# Altium schematic sheet Main.SchDoc(Main)
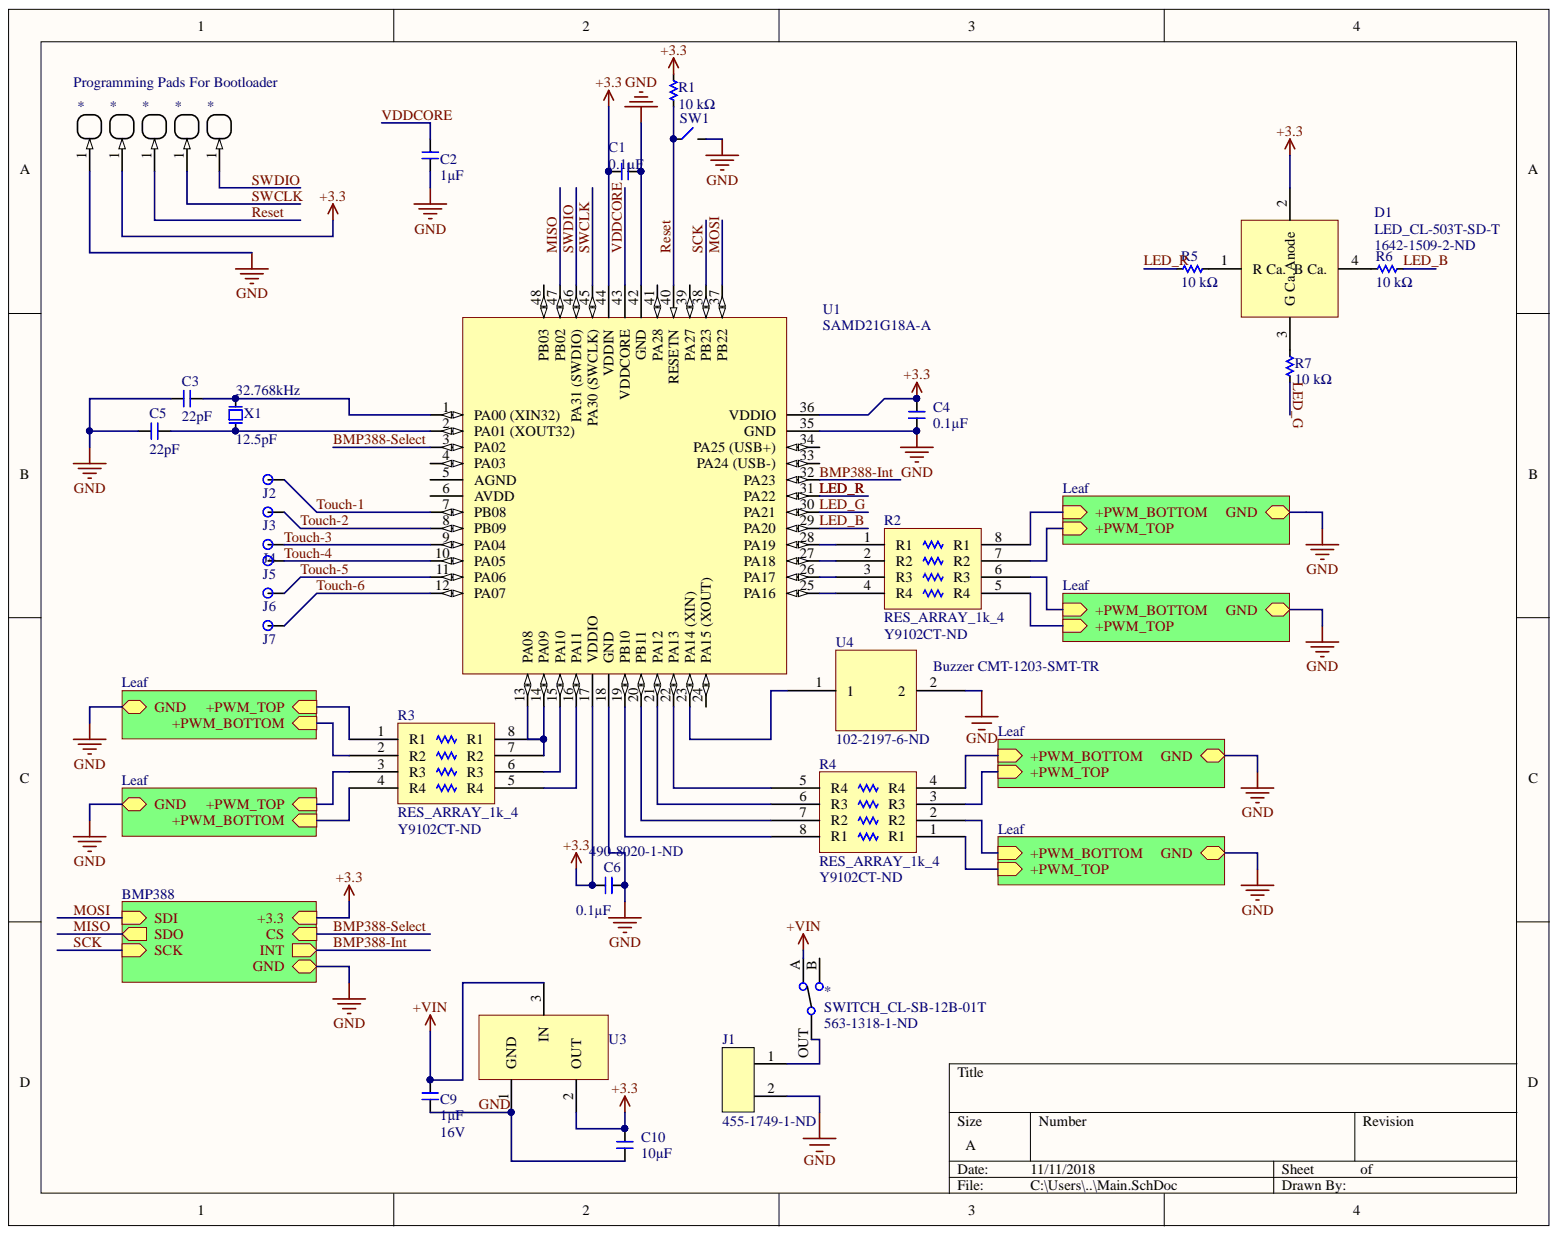
[diagram p0: Main.SchDoc]

## Parts
*  EWOD_PAD  [EWOD-PAD-2MM]  (value not drawn)
*  EWOD_PAD  [EWOD-PAD-2MM]  (value not drawn)
*  EWOD_PAD  [EWOD-PAD-2MM]  (value not drawn)
*  EWOD_PAD  [EWOD-PAD-2MM]  (value not drawn)
*  EWOD_PAD  [EWOD-PAD-2MM]  (value not drawn)
*  SWITCH_CL-SB-12B-01T  Supplier Part Number 1: 563-1318-1-ND  [SWITCH_CL-SB-12B-01T]
C1  Capacitance (shorthand): 0.1µF  [C0603]  (hidden comment: __template_cap)
C2  Capacitance (shorthand): 1µF  [C0603]  (hidden comment: __template_cap)
C3  Capacitance (shorthand): 22pF  [C0603]  (hidden comment: __template_cap)
C4  Capacitance (shorthand): 0.1µF  [C0603]  (hidden comment: __template_cap)
C5  Capacitance (shorthand): 22pF  [C0603]  (hidden comment: __template_cap)
C6  Supplier Part Number 2: 490-8020-1-ND  Capacitance (shorthand): 0.1µF  [C0603]  (hidden comment: __template_cap)
C9  Rated Voltage: 16V  Capacitance (shorthand): 1µF  [C0603]  (hidden comment: __template_cap)
C10  Capacitance (shorthand): 10µF  [C0603]  (hidden comment: __template_cap)
D1  LED_CL-503T-SD-T  Supplier Part Number 1: 1642-1509-2-ND  [LED_RGB_CL-503T-SD-T]
J1  Supplier Part Number 1: 455-1749-1-ND  [CONN_JST_PH_SM4_2PIN_RA]  (hidden comment: CONN JST 2POS SMD)
J2  CONN_1x1  [CONN_1x1_100MIL]  (value not drawn)
J3  CONN_1x1  [CONN_1x1_100MIL]  (value not drawn)
J4  CONN_1x1  [CONN_1x1_100MIL]  (value not drawn)
J5  CONN_1x1  [CONN_1x1_100MIL]  (value not drawn)
J6  CONN_1x1  [CONN_1x1_100MIL]  (value not drawn)
J7  CONN_1x1  [CONN_1x1_100MIL]  (value not drawn)
R1  __template_resistor  [R0603]  (value not drawn)
R2  RES_ARRAY_1k_4  [RES_ARRAY_1k]
R3  RES_ARRAY_1k_4  Supplier Part Number 1: Y9102CT-ND  [RES_ARRAY_1k]
R4  RES_ARRAY_1k_4  Supplier Part Number 1: Y9102CT-ND  [RES_ARRAY_1k]
R5  Resistance: 10 kΩ  [R0603]  (hidden comment: __template_resistor)
R6  Resistance: 10 kΩ  [R0603]  (hidden comment: __template_resistor)
R7  Resistance: 10 kΩ  [R0603]  (hidden comment: __template_resistor)
SW1  SWITCH_SPST-NO  [SWITCH_B3U-1000P]  (value not drawn)
U1  SAMD21G18A-A  [SAMD21 TQFP-48]
U3  LDO_AP2210N-3.3TRG1  [3SOT23]  (value not drawn)
U4  Buzzer CMT-1203-SMT-TR  Supplier Part Number 1: 102-2197-6-ND  [CMT-1203-SMT-TR]
X1  Load Capacitance: 12.5pF  Frequency: 32.768kHz  [XTAL_TXC_9HT10]  (hidden comment: XTAL_9HT10-32.768KEZF-T)

## Nets
+3.3: *.1 C1.2 C4.2 C6.1 C10.1 D1.2 R1.2 U1.17(VDDIO) U1.36(VDDIO) U1.44(VDDIN) U3.2
+VIN: *.A C9.1 U3.3
BMP388-Int: U1.32(PA23)
BMP388-Select: U1.3(PA02)
GND: *.1 C1.1 C2.2 C3.1 C4.1 C5.1 C6.2 C9.2 C10.2 J1.2 SW1.2 U1.18(GND) U1.35(GND) U1.42(GND) U3.1 U4.2
LED_B: R6.2 U1.29(PA20)
LED_G: R7.1 U1.30(PA21)
LED_R: R5.2 U1.31(PA22)
MISO: U1.47(PB02)
MOSI: U1.37(PB22)
Reset: *.1 R1.1 SW1.1 U1.40(RESETN)
SCK: U1.38(PB23)
SWCLK: *.1 U1.45(PA30_(SWCLK))
SWDIO: *.1 U1.46(PA31_(SWDIO))
Touch-1: J2.1 U1.7(PB08)
Touch-2: J3.1 U1.8(PB09)
Touch-3: J4.1 U1.9(PA04)
Touch-4: J5.1 U1.10(PA05)
Touch-5: J6.1 U1.11(PA06)
Touch-6: J7.1 U1.12(PA07)
VDDCORE: C2.1 U1.43(VDDCORE)
Net*_B: *.B
Net*_OUT: *.OUT J1.1
NetC3_2: C3.2 U1.1(PA00_(XIN32)) X1.2
NetC5_2: C5.2 U1.2(PA01_(XOUT32)) X1.1
NetD1_1: D1.1(R_Ca.) R5.1
NetD1_3: D1.3(G_Ca.) R7.2
NetD1_4: D1.4(B_Ca.) R6.1
NetR2_1: R2.1(R1) U1.28(PA19)
NetR2_2: R2.2 U1.27(PA18)
NetR2_3: R2.3(R3) U1.26(PA17)
NetR2_4: R2.4(R4) U1.25(PA16)
NetR2_5: R2.5(R4)
NetR2_6: R2.6(R3)
NetR2_7: R2.7
NetR2_8: R2.8(R1)
NetR3_1: R3.1(R1)
NetR3_2: R3.2(R2)
NetR3_3: R3.3
NetR3_4: R3.4(R4)
NetR3_5: R3.5(R4) U1.16(PA11)
NetR3_6: R3.6 U1.15(PA10)
NetR3_7: R3.7(R2) R3.8(R1) U1.13(PA08) U1.14(PA09)
NetR4_1: R4.1(R1)
NetR4_2: R4.2(R2)
NetR4_3: R4.3(R3)
NetR4_4: R4.4
NetR4_5: R4.5 U1.22(PA13)
NetR4_6: R4.6(R3) U1.21(PA12)
NetR4_7: R4.7(R2) U1.20(PB11)
NetR4_8: R4.8(R1) U1.19(PB10)
NetU1_4: U1.4(PA03)
NetU1_5: U1.5(AGND)
NetU1_6: U1.6(AVDD)
NetU1_23: U1.23(PA14_(XIN)) U4.1
NetU1_24: U1.24(PA15_(XOUT))
NetU1_33: U1.33(PA24_(USB-))
NetU1_34: U1.34(PA25_(USB+))
NetU1_39: U1.39(PA27)
NetU1_41: U1.41(PA28)
NetU1_48: U1.48(PB03)

## Library data of the parts
* = SWITCH_CL-SB-12B-01T: Manufacturer: Copal Electronics Inc.; Voltage Rating - DC: 12V; Switch Travel: 2.00mm; Switch Function: On-On; Operating Force: 153gf; Electrical Life: 10,000 Cycles; Current Rating: 200mA (DC); Contact Timing: Non-Shorting (BBM); Circuit: SPDT; Actuator Type: Standard; Actuator Material: Polyamide (PA), Nylon; Voltage Rating - AC: -; Termination Style: Gull Wing; Supplier 1: Digi-Key; Stock 1: 24034; RoHS: RoHS Compliant; Pricing 1: 1=0.89, 10=0.853, 25=0.8356, 50=0.7466, 100=0.711, 250=0.69328 (USD); Part Status: Active; Packaging: Cut Tape (CT); Operating Temperature: -40°C ~ 85°C; Mounting Type: Surface Mount; Mechanical Life: -; Manufacturer Part Number: CL-SB-12B-01T; Features: -; Contact Material: Copper; Contact Finish: Silver; ComponentLink1URL: http://www.nidec-copal-electronics.com/e/catalog/switch/cl-sb.pdf; ComponentLink1Description: http://www.nidec-copal-electronics.com/e/catalog/switch/cl-sb.pdf; Component Kind: Standard; Category: Switches; Actuator Length: 2.00mm; Library Name: SSI.IntLib
C1 C4 C6 = CAP CER 0603 0.1µF X7R 50V 10%: Manufacturer: Murata Electronics North America; type: capacitor; Dielectric: X7R; Database Table Name: C0603$; Capacitance - pF (Machine readable): 100000; Supplier Part Number 1: 478-5052-1-ND; Supplier 2: Digi-Key; Supplier 1: Digikey; Signal Integrity: Guessed Model; Library Name: passives.DbLib; Component Kind: Standard
  C1 C4: Supplier Part Number 2: 490-8020-1-ND
C2 = CAP CER 0603 1µF X7R 16V 10%: Manufacturer: Murata Electronics North America; TYPE: Capacitor; Dielectric: X7R; Database Table Name: C0603$; Capacitance - pF (Machine readable): 1000000; Supplier Part Number 1: 490-10479-1-ND; Supplier 1: Digi-Key; Signal Integrity: Guessed Model; Library Name: passives.DbLib; Component Kind: Standard
C3 C5 = CAP CER 22PF 50V C0G/NP0 0603: Database Table Name: C0603$; Supplier Part Number 1: 478-5771-1-ND; Supplier 1: Digi-Key; Signal Integrity: Guessed Model; Library Name: passives.DbLib; Component Kind: Standard
C9 = CAP CER 0603 1µF X7R 16V 10%: Manufacturer: Murata Electronics North America; type: capacitor; Dielectric: X7R; Database Table Name: C0603$; Capacitance - pF (Machine readable): 1000000; Supplier Part Number 1: 490-3900-1-ND; Supplier 1: Digikey; Library Name: passives.DbLib; Component Kind: Standard
C10 = CAP CER 10UF 35V X5R 0603 20%: Manufacturer: Murata Electronics North America; type: capacitor; Dielectric: X5R; Database Table Name: C0603$; Capacitance - pF (Machine readable): 10000000; Supplier Part Number 1: 490-13248-1-ND; Supplier 1: Digikey; Signal Integrity: Guessed Model; Library Name: passives.DbLib; Component Kind: Standard
D1 = LED_CL-503T-SD-T: RGB LOW VOLTAGE RICOLOR TOP FIRING 1.5 X 1.3 X; Manufacturer: Citizen; Wavelength - Peak: 618nm Red, 530nm Green, 470nm Blue; Voltage - Forward (Vf) (Typ): 1.85V Red, 2.7V Green, 2.75V Blue; Millicandela Rating: 36mcd Red, 180mcd Green, 40mcd Blue; Lens Style/Size: Rectangle with Flat Top, 1.30mm x 1.50mm; Current - Test: 5mA Red, 5mA Green, 5mA Blue; Configuration: Common Anode; Color: Red, Green, Blue (RGB); Wavelength - Dominant: -; Viewing Angle: -; Supplier Device Package: -; Supplier 1: Digi-Key; Stock 1: 15000; Size / Dimension: 1.50mm L x 1.30mm W; RoHS: RoHS Compliant; Pricing 1: 5000=0.2318, 10000=0.22836, 25000=0.22321 (USD); Part Status: Active; Packaging: Tape & Reel (TR); Package / Case: 4-SMD, No Lead; Mounting Type: Surface Mount; Manufacturer Part Number: CL-503T-SD-T; Lens Transparency: -; Lens Color: -; Height (Max): 0.35mm; Features: -; ComponentLink1URL: http://ce.citizen.co.jp/up_img/product/KF9Gun57LXzH/CL-503_e.pdf; ComponentLink1Description: http://ce.citizen.co.jp/up_img/product/KF9Gun57LXzH/CL-503_e.pdf; Component Kind: Standard; Category: Optoelectronics; Library Name: SSI.IntLib
J1 = CONN_JST_2_PH: CONN HEADER PH SIDE 2POS 2MM SMD; Manufacturer Part Number: S2B-PH-SM4-TB(LF)(SN); Manufacturer: JST Sales America Inc.; Pitch: 0.079" (2.00mm); Number of Positions Loaded: All; Number of Positions: 2; Connector Type: Header, Shrouded; Termination: Solder; Supplier 1: Digi-Key; Stock 1: 148568; Row Spacing: -; RoHS: RoHS Compliant; Pricing 1: 1=0.56, 10=0.521, 25=0.4688, 50=0.4168, 100=0.3994, 250=0.36472, 500=0.34734 (USD); Packaging: Cut Tape (CT); Number of Rows: 1; Mounting Type: Surface Mount, Right Angle; Features: Solder Retention; Fastening Type: Detent Lock; Contact Type: Male Pin; Contact Mating Length: 0.130" (3.30mm); Contact Finish Thickness: -; Contact Finish: Tin; ComponentLink1URL: http://www.jst-mfg.com/product/pdf/eng/ePH.pdf; ComponentLink1Description: http://www.jst-mfg.com/product/pdf/eng/ePH.pdf; Component Kind: Standard; Color: Natural; Category: Connectors, Interconnects; Library Name: SSI.IntLib
J2-J7 = CONN_1x1: Just a hole, lol; Component Kind: Standard; Library Name: SSI.IntLib
R1 = RES SMD 10K OHM 1% 1/10W 0603: Manufacturer Part Number: RC0603FR-0710KL; Manufacturer: Yageo; Tolerance: ±1%; Temperature Coefficient: ±100ppm/°C; Resistance (Sortable): 10000; Resistance: 10 kΩ; Power (Watts): 0.1W, 1/10W; Database Table Name: R0603$; Supplier Part Number 1: 541-1784-1-ND; Supplier 1: Digikey; Signal Integrity: Guessed Model; Operating Temperature: -55°C ~ 155°C; Library Name: passives.DbLib; Image: //media.digikey.com/Renders/Yageo%20Renders/0603-(1608-Metric).jpg; Datasheets: http://www.yageo.com/documents/recent/PYu-RC_Group_51_RoHS_L_9.pdf; Component Kind: Standard
R2-R4 = RES_ARRAY_1k_4: RES ARRAY 4 RES 1K OHM 1206; Supplier 1: Digi-Key; Component Kind: Standard; Library Name: SSI.IntLib
  R2: Supplier Part Number 1: Y9102CT-ND
R5-R7 = RES SMD 10K OHM 1% 1/10W 0603: Manufacturer Part Number: RC0603FR-0710KL; Manufacturer: Yageo; Tolerance: ±1%; Temperature Coefficient: ±100ppm/°C; Resistance (Sortable): 10000; Power (Watts): 0.1W, 1/10W; Database Table Name: R0603$; Supplier Part Number 1: 311-10.0KHRCT-ND; Supplier 1: Digikey; Operating Temperature: -55°C ~ 155°C; Library Name: passives.DbLib; Image: //media.digikey.com/Renders/Yageo%20Renders/0603-(1608-Metric).jpg; Datasheets: http://www.yageo.com/documents/recent/PYu-RC_Group_51_RoHS_L_9.pdf; Component Kind: Standard
SW1 = SWITCH_SPST-NO: SWITCH TACTILE SPST-NO 0.05A 12V; Manufacturer Part Number: B3U-1000P; Manufacturer: Omron Electronics Inc-EMC Div; Switch Travel: 0.15mm; Switch Function: Off-Mom; Outline: 3.00mm x 2.50mm; Operating Force: 153gf; Mechanical Life: 200,000 Cycles; Ingress Protection: Dust Proof; Illumination: Non-Illuminated; Contact Rating @ Voltage: 0.05A @ 12VDC; Actuator Type: Standard; Actuator Orientation: Top Actuated; Actuator Height off PCB, from Pins: 1.60mm; Termination Style: SMD (SMT) Tab; Supplier Part Number 1: SW1020CT-ND; Supplier 1: Digi-Key; Stock 1: 16437; RoHS: RoHS Compliant; Pricing 1: 1=1.25, 10=1.201, 25=1.1332, 50=0.997, 100=0.9064, 250=0.86108, 500=0.83842, 1000=0.65714 (USD); Packaging: Cut Tape (CT); Operating Temperature: -25°C ~ 70°C; Mounting Type: Surface Mount; Illumination Voltage (Nominal): -; Illumination Type, Color: -; Features: -; ComponentLink1URL: http://components.omron.com/components/web/pdflib.nsf/0/2DD3893C7DD33E9E862572900079E9D6/$file/B3U_1110.pdf; ComponentLink1Description: http://components.omron.com/components/web/pdflib.nsf/0/2DD3893C7DD33E9E862572900079E9D6/$file/B3U_1110.pdf; Component Kind: Standard; Circuit: SPST-NO; Category: Switches; Actuator Material: -; Library Name: SSI.IntLib
U1 = SAMD21G18A-A: 48Mhz Atmel SAM ARM Cortex M0+; Supplier Part Number 1: ATSAMD21G18A-AU-ND; Supplier 1: Digi-Key; ComponentLink2URL: http://ww1.microchip.com/downloads/en/DeviceDoc/SAM-D21-Family-Datasheet-DS40001882C.pdf; ComponentLink2Description: Datasheet; ComponentLink1URL: https://www.microchip.com/wwwproducts/en/ATSAMD21G18; ComponentLink1Description: Product Page; Component Kind: Standard; Library Name: SSI.IntLib
U3 = LDO_AP2210N-3.3TRG1: IC REG LINEAR 3.3V 300MA SOT23-3; Manufacturer: Diodes; Quiescent Current: 150uA; Power Supply Rejection Ratio (PSRR): 75dB; Polarity: Positive; Number of Regulators: 1; Min Input Voltage: 2.5V; Max Input Voltage: 13.2V; Dropout Voltage: 250mV; Accuracy: 1%; Width: 1.7mm; Weight: 0.000282oz; Supplier Part Number 1: AP2210N-3.3TRG1DICT-ND; Supplier 1: Digi-Key; Stock 1: 36056; Pricing 1: 1=0.42, 10=0.317, 100=0.1973, 500=0.135, 1000=0.1038 (USD); Packaging: Tape and Reel; Package Quantity: 3000; Output Voltage: 3.3V; Output Current: 300mA; Number of Pins: 3; Number of Outputs: 1; Mount: Surface Mount; Min Operating Temperature: -40°C; Max Output Current: 300mA; Max Operating Temperature: 125°C; Manufacturer Part Number: AP2210N-3.3TRG1; Length: 3.02mm; Height: 1.3mm; ComponentLink1URL: https://datasheet.ciiva.com/29569/ap2210-29569040.pdf; ComponentLink1Description: https://datasheet.ciiva.com/29569/ap2210-29569040.pdf; Component Kind: Standard; Case/Package: TO-236-3; Library Name: SSI.IntLib
U4 = BUZ_CMT-1203-SMT-TR: AUDIO PIEZO TRANSDUCER 25V SMD; Supplier 1: Digi-Key; Component Kind: Standard; Library Name: SSI.IntLib
X1 = XTAL_9HT10-32.768KEZF-T: CRYSTAL 32.768 KHZ 12.5 PF SMD; Manufacturer: TXC CORPORATION; Operating Mode: Fundamental; Frequency Tolerance: ±10ppm; ESR (Equivalent Series Resistance): 70 kOhm; Type: kHz Crystal (Tuning Fork); Supplier Part Number 1: 887-1507-1-ND; Supplier 1: Digi-Key; Stock 1: 5250; Size / Dimension: 0.126" L x 0.059" W (3.20mm x 1.50mm); RoHS: RoHS Compliant; Ratings: -; Pricing 1: 1=1.88, 10=1.625, 50=1.425, 100=1.125, 500=0.95, 1000=0.75 (USD); Packaging: Cut Tape (CT); Package / Case: 2-SMD; Operating Temperature: -40°C ~ 85°C; Mounting Type: Surface Mount; Manufacturer Part Number: 9HT10-32.768KEZF-T; Height: 0.033" (0.85mm); Frequency Stability: -; ComponentLink1URL: http://www.txccrystal.com/images/pdf/9ht10.pdf; ComponentLink1Description: Datasheet; Component Kind: Standard; Category: Crystals and Oscillators; Library Name: SSI.IntLib
* * * * * = EWOD_PAD: Component Kind: Standard; Library Name: SSI.IntLib
